# Altium schematic sheet Power Supply.SchDoc
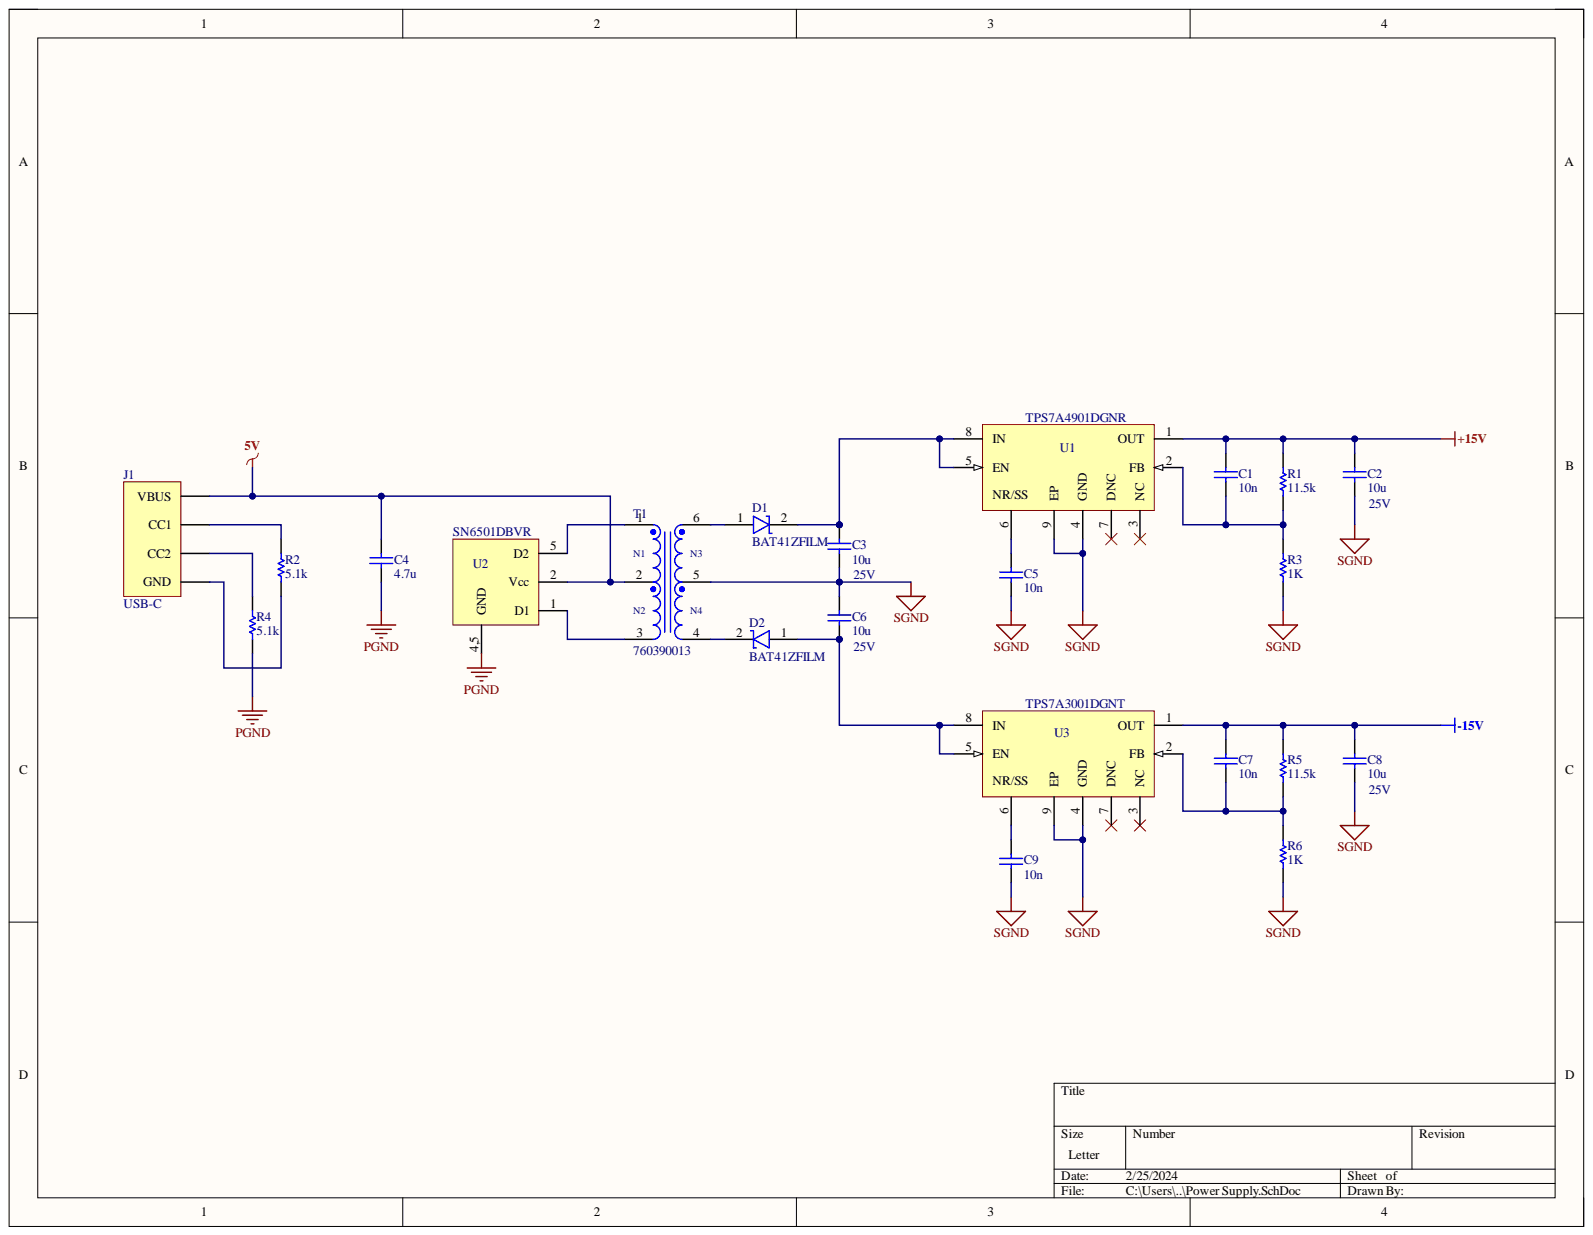
[diagram p0: Power Supply.SchDoc]

## Parts
C1  value 10n  (hidden comment: Capacitor)
C2  25V  value 10u
C3  25V  value 10u
C4  value 4.7u  (hidden comment: Capacitor)
C5  value 10n  (hidden comment: Capacitor)
C6  25V  value 10u
C7  value 10n  (hidden comment: Capacitor)
C8  25V  value 10u
C9  value 10n  (hidden comment: Capacitor)
D1  BAT41ZFILM
D2  BAT41ZFILM
J1  USB-C  [FP-USB4125-GF-A-0190-MFG]
R1  value 11.5k  (hidden comment: Resistor)
R2  value 5.1k  (hidden comment: Resistor)
R3  value 1K  (hidden comment: Resistor)
R4  value 5.1k  (hidden comment: Resistor)
R5  value 11.5k  (hidden comment: Resistor)
R6  value 1K  (hidden comment: Resistor)
T1  760390013  [WE-PPTI_1006_L10.2W6.73H4.19]
U1  TPS7A4901DGNR  [DGN0008D_M]
U2  SN6501DBVR
U3  TPS7A3001DGNT  [DGN0008D_M]

## Nets
5V: C4.2 J1.1(VBUS) T1.2 U2.2(Vcc)
+15V: C1.2 C2.2 R1.2 U1.1(OUT)
-15V: C7.2 C8.2 R5.2 U3.1(OUT)
PGND: C4.1 R4.1 U2.4,5(GND)
SGND: C2.1 C3.1 C5.1 C6.2 C8.1 C9.1 R3.1 R6.1 T1.5 U1.4(GND) U1.9(EP) U3.4(GND) U3.9(EP)
NetC1_1: C1.1 R1.1 R3.2 U1.2(FB)
NetC3_2: C3.2 D1.2 U1.5(EN) U1.8(IN)
NetC5_2: C5.2 U1.6
NetC6_1: C6.1 D2.1 U3.5(EN) U3.8(IN)
NetC7_1: C7.1 R5.1 R6.2 U3.2(FB)
NetC9_2: C9.2 U3.6
NetD1_1: D1.1 T1.6
NetD2_2: D2.2 T1.4
NetJ1_2: J1.2(CC1) R2.2
NetJ1_3: J1.3(CC2) R4.2
NetJ1_4: J1.4(GND) R2.1
NetT1_1: T1.1 U2.5(D2)
NetT1_3: T1.3 U2.1(D1)

Not connected: U1 3(NC) 7(DNC); U3 3(NC) 7(DNC)

## Library data of the parts
C1-C9 = Capacitor: SimulationGenericComponent: True; Component Kind: Standard; Description: Capacitor; Library Name: Simulation Generic Components.IntLib; Simulation: C_Capacitor_Default
D1 D2 = BAT41ZFILM: Rectifier Diode, Schottky, 1 Phase, 1 Element, 0.2A, 100V V(RRM), Silicon; Manufacturer: STMicroelectronics; Forward Voltage: 450mV; Max Output current: 200mA; Non-rep Pk Forward Current-Max: 1A; Number of Elements: 1; Package Body Material: Plastic; Qualification Status: Not Qualified; Time @ Peak Reflow Temperature-Max (s): 30s; Category: Rectifier Diodes; Component Kind: Standard; ComponentLink1Description: https://datasheet.ciiva.com:443/pdfs/VipMasterIC/IC/SGST/SGSTS35734/SGSTS35734-1.pdf?src-supplier=IHS+Markit; ComponentLink1URL: https://datasheet.ciiva.com:443/pdfs/VipMasterIC/IC/SGST/SGSTS35734/SGSTS35734-1.pdf?src-supplier=IHS+Markit; ComponentLink2Description: https://datasheet.ciiva.com/1242/cd00130227-1242625.pdf?src-supplier=Newark; ComponentLink2URL: https://datasheet.ciiva.com/1242/cd00130227-1242625.pdf?src-supplier=Newark; ComponentLink3Description: https://datasheet.ciiva.com/46385/1689714-46385901.pdf?src-supplier=Element14; ComponentLink3URL: https://datasheet.ciiva.com/46385/1689714-46385901.pdf?src-supplier=Element14; ComponentLink4Description: https://datasheet.ciiva.com/46385/1689714-46385899.pdf?src-supplier=Element14; ComponentLink4URL: https://datasheet.ciiva.com/46385/1689714-46385899.pdf?src-supplier=Element14; ComponentLink5Description: https://datasheet.ciiva.com/16421/cd00130227-16421670.pdf?src-supplier=Future+Electronics; ComponentLink5URL: https://datasheet.ciiva.com/16421/cd00130227-16421670.pdf?src-supplier=Future+Electronics; ComponentLink6Description: https://datasheet.ciiva.com/22733/1689714-22733175.pdf?src-supplier=Element14; ComponentLink6URL: https://datasheet.ciiva.com/22733/1689714-22733175.pdf?src-supplier=Element14; ComponentLink7Description: https://datasheet.ciiva.com/915/cd00130227-915684.pdf?src-supplier=Digikey; ComponentLink7URL: https://datasheet.ciiva.com/915/cd00130227-915684.pdf?src-supplier=Digikey; ComponentLink8Description: https://datasheet.ciiva.com/915/cd00130227-915683.pdf?src-supplier=Digikey; ComponentLink8URL: https://datasheet.ciiva.com/915/cd00130227-915683.pdf?src-supplier=Digikey; ComponentLink9Description: https://datasheet.ciiva.com/915/cd00130227-915685.pdf?src-supplier=Digikey; ComponentLink9URL: https://datasheet.ciiva.com/915/cd00130227-915685.pdf?src-supplier=Digikey; ComponentLink10Description: https://datasheet.ciiva.com/15192/getdatasheetpartid-141692-15192973.pdf?src-supplier=Verical; ComponentLink10URL: https://datasheet.ciiva.com/15192/getdatasheetpartid-141692-15192973.pdf?src-supplier=Verical; Diode Element Material: SILICON; Diode Type: RECTIFIER; JESD-30 Code: R-PDSO-G2; JESD-609 Code: e3; Manufacturer Part Number: BAT41ZFILM; Max Operating Temperature: 150°C; Moisture Sensitivity Level: 1; Package Shape: Rectangular; Package Style: SMALL OUTLINEMeter; Peak Reflow Temperature (Cel): 260°C; Pins: 2; Pricing: 1=0.33, 10=0.232, 50=0.232, 100=0.117, 1000=0.071, 10000=0.049 (USD); Reach SVHC Compliant: Yes; Rep Pk Reverse Voltage-Max: 100V; RoHS: Yes; RoHS Compliant: Yes; Stock: 43204; Supplier: Mouser; Supplier Part Number: 511-BAT41ZFILM; Surface Mount: Yes; Technology: SCHOTTKY; Terminal Finish: Tin; Terminal Form: Gull Wing; Terminal Position: DUAL; Library Name: DRC.SchLib
J1 = USB-C: Generic USB-C Connector, Female; Component Kind: Standard; Library Name: DRC.SchLib
R1-R6 = Resistor: SimulationGenericComponent: True; TC1: 0; Component Kind: Standard; Description: Resistor; Library Name: Simulation Generic Components.IntLib; Simulation: R_Resistor_Default; TC2: 0
T1 = WE-PPTI_1006: TRANSFORMER 475UH SMD; NexarPartId: 26029731; Current Rating: 150mA; DC Resistance (DCR): 470mΩ; Frequency: 10kHz; Inductance: 475µH; Isolation Voltage: 2.5kV; Turns Ratio: 1:1.7; Case/Package: SMD/SMT; Component Kind: Standard; Height: 4.19mm; Height - Seated (Max): 4.19mm; Length: 10.05mm; Max DC Current: 150mA; Max Operating Temperature: 125°C; Min Operating Temperature: -40°C; Mount: Surface Mount; Packaging: Digi-Reel®; RoHS: Compliant; Termination: SMD/SMT; Width: 6.73mm; Library Name: DRC.SchLib
U1 = TPS7A4901DGNR: Adjustable Positive LDO Regulator, 1.176V Min, 33V Max, 0.6V Dropout, BIPolar, PDSO8; Manufacturer: Texas Instruments; Channels: 1; Dropout Voltage1-Max: 600mV; Dropout Voltage1-Nom: 333mV; Output Current1-Max: 150mA; Output Voltage1-Min: 1.194V; Package Body Material: Plastic; Package Equivalence Code: TSSOP8,.19; Qualification Status: Not Qualified; Regulator Type: ADJUSTABLE POSITIVE SINGLE OUTPUT LDO REGULATOR; Adjustability: ADJUSTABLE; Case/Package: HTSSOP; Category: Voltage Regulators - Linear; Component Kind: Standard; ComponentLink1Description: https://www.ti.com:443/lit/ds/symlink/tps7a49.pdf?src-supplier=IHS+Markit; ComponentLink1URL: https://www.ti.com:443/lit/ds/symlink/tps7a49.pdf?src-supplier=IHS+Markit; ComponentLink2Description: https://datasheet.ciiva.com/4885/sbvs121b-126024-4885345.pdf?src-supplier=Mouser; ComponentLink2URL: https://datasheet.ciiva.com/4885/sbvs121b-126024-4885345.pdf?src-supplier=Mouser; ComponentLink3Description: https://datasheet.ciiva.com/41462/001015155-da-01-en-ic-reg-ldo-adj-tps7a4901dgnr-vssop-8-tid-41462999.pdf?src-supplier=Conrad; ComponentLink3URL: https://datasheet.ciiva.com/41462/001015155-da-01-en-ic-reg-ldo-adj-tps7a4901dgnr-vssop-8-tid-41462999.pdf?src-supplier=Conrad; ComponentLink4Description: https://datasheet.ciiva.com/7547/1857485-7547109.pdf?src-supplier=Newark; ComponentLink4URL: https://datasheet.ciiva.com/7547/1857485-7547109.pdf?src-supplier=Newark; ComponentLink5Description: https://datasheet.ciiva.com/14275/sbvs121b-14275869.pdf?src-supplier=Avnet; ComponentLink5URL: https://datasheet.ciiva.com/14275/sbvs121b-14275869.pdf?src-supplier=Avnet; Height - Seated (Max): 1.1mm; JESD-30 Code: S-PDSO-G8; JESD-609 Code: e4; Length: 3mm; Manufacturer Part Number: TPS7A4901DGNR; Moisture Sensitivity Level: 2; Number of Outputs: 1; Operating Temperature, TJ-Max: 125°C; Operating Temperature, TJ-Min: -40°C; Output Voltage1-Max: 33V; Package Shape: Square; Package Style: SMALL OUTLINE, HEAT SINK/SLUG, THIN PROFILE, SHRINK PITCHMeter; Packaging: Tape and Reel; Peak Reflow Temperature (Cel): 260°C; Pins: 8; Pricing: 1=2.84, 10=2.56, 50=2.56, 100=2.09, 1000=1.5, 10000=1.37 (USD); Reach SVHC Compliant: Yes; RoHS: Yes; RoHS Compliant: Yes; Stock: 5747; Supplier: Mouser; Supplier Part Number: 595-TPS7A4901DGNR; Surface Mount: Yes; Technology: BIPOLAR; Terminal Finish: Nickel; Terminal Form: Gull Wing; Terminal Pitch: 0.65mm; Terminal Position: DUAL; Width: 3mm; Library Name: DRC.SchLib
U2 = SN6501DBVR: Interface Circuit, CMOS, PDSO5; Manufacturer: Texas Instruments; Channels: 1; Max Supply Voltage: 5.5V; Min Supply Voltage: 3V; Nominal Supply Voltage: 3.3V; Package Body Material: Plastic; Package Equivalence Code: TSOP5/6,.11,37; Power Supplies: 3.3/5V; Qualification Status: Not Qualified; Case/Package: LSSOP; Category: Power Management ICs; Component Kind: Standard; ComponentLink1Description: https://www.ti.com:443/lit/ds/symlink/sn6501.pdf?src-supplier=IHS+Markit; ComponentLink1URL: https://www.ti.com:443/lit/ds/symlink/sn6501.pdf?src-supplier=IHS+Markit; ComponentLink2Description: https://datasheet.ciiva.com/41798/sn6501-41798985.pdf?src-supplier=Digikey; ComponentLink2URL: https://datasheet.ciiva.com/41798/sn6501-41798985.pdf?src-supplier=Digikey; Height - Seated (Max): 1.45mm; Interface IC Type: INTERFACE CIRCUIT; JESD-30 Code: R-PDSO-G5; JESD-609 Code: e4; Length: 2.9mm; Manufacturer Part Number: SN6501DBVR; Max Operating Temperature: 125°C; Min Operating Temperature: -40°C; Moisture Sensitivity Level: 1; Package Shape: Rectangular; Package Style: SMALL OUTLINE, LOW PROFILE, SHRINK PITCHMeter; Peak Reflow Temperature (Cel): 260°C; Pins: 5; Pricing: 1=2.04, 10=1.8, 50=1.8, 100=1.47, 1000=1.01, 10000=0.88 (USD); Reach SVHC Compliant: Yes; RoHS: Yes; RoHS Compliant: Yes; Stock: 54147; Supplier: Mouser; Supplier Part Number: 595-SN6501DBVR; Surface Mount: Yes; Technology: CMOS; Temperature Grade: AUTOMOTIVE; Terminal Finish: Nickel; Terminal Form: Gull Wing; Terminal Pitch: 0.95mm; Terminal Position: DUAL; Width: 1.6mm; Library Name: DRC.SchLib
U3 = TPS7A3001DGNT: Adjustable Negative LDO Regulator, 1.18V Min, 33V Max, 0.6V Dropout, BIPolar, PDSO8; Manufacturer: Texas Instruments; Channels: 1; Dropout Voltage1-Max: 600mV; Dropout Voltage1-Nom: 325mV; Output Current1-Max: 200mA; Package Body Material: Plastic; Package Equivalence Code: TSSOP8,.19; Qualification Status: Not Qualified; Regulator Type: ADJUSTABLE NEGATIVE SINGLE OUTPUT LDO REGULATOR; Adjustability: ADJUSTABLE; Case/Package: HTSSOP; Category: Voltage Regulators - Linear; Component Kind: Standard; ComponentLink1Description: https://www.ti.com:443/lit/ds/symlink/tps7a30.pdf?src-supplier=IHS+Markit; ComponentLink1URL: https://www.ti.com:443/lit/ds/symlink/tps7a30.pdf?src-supplier=IHS+Markit; ComponentLink2Description: https://datasheet.ciiva.com/22733/1726442-22733400.pdf?src-supplier=Farnell; ComponentLink2URL: https://datasheet.ciiva.com/22733/1726442-22733400.pdf?src-supplier=Farnell; ComponentLink3Description: https://datasheet.ciiva.com/4885/sbvs125a-126025-4885255.pdf?src-supplier=Mouser; ComponentLink3URL: https://datasheet.ciiva.com/4885/sbvs125a-126025-4885255.pdf?src-supplier=Mouser; ComponentLink4Description: https://datasheet.ciiva.com/14276/tps7a3001-14276192.pdf?src-supplier=Avnet; ComponentLink4URL: https://datasheet.ciiva.com/14276/tps7a3001-14276192.pdf?src-supplier=Avnet; Height - Seated (Max): 1.1mm; JESD-30 Code: S-PDSO-G8; JESD-609 Code: e4; Length: 3mm; Manufacturer Part Number: TPS7A3001DGNT; Moisture Sensitivity Level: 2; Number of Outputs: 1; Operating Temperature, TJ-Max: 125°C; Operating Temperature, TJ-Min: -40°C; Output Voltage1-Max: 33V; Output Voltage1-Min: 1.18V; Package Shape: Square; Package Style: SMALL OUTLINE, HEAT SINK/SLUG, THIN PROFILE, SHRINK PITCHMeter; Packaging: Tape and Reel; Peak Reflow Temperature (Cel): 260°C; Pins: 8; Pricing: 1=4.76, 10=4.19, 50=4.19, 100=3.5, 250=3.32, 500=2.98, 1000=2.52, 2500=2.39, 10000=2.39 (USD); Reach SVHC Compliant: Yes; RoHS: Yes; RoHS Compliant: Yes; Stock: 15878; Supplier: Mouser; Supplier Part Number: 595-TPS7A3001DGNT; Surface Mount: Yes; Technology: BIPOLAR; Terminal Finish: Nickel; Terminal Form: Gull Wing; Terminal Pitch: 0.65mm; Terminal Position: DUAL; Width: 3mm; Library Name: DRC.SchLib
